# Altium schematic sheet Sheet1.SchDoc
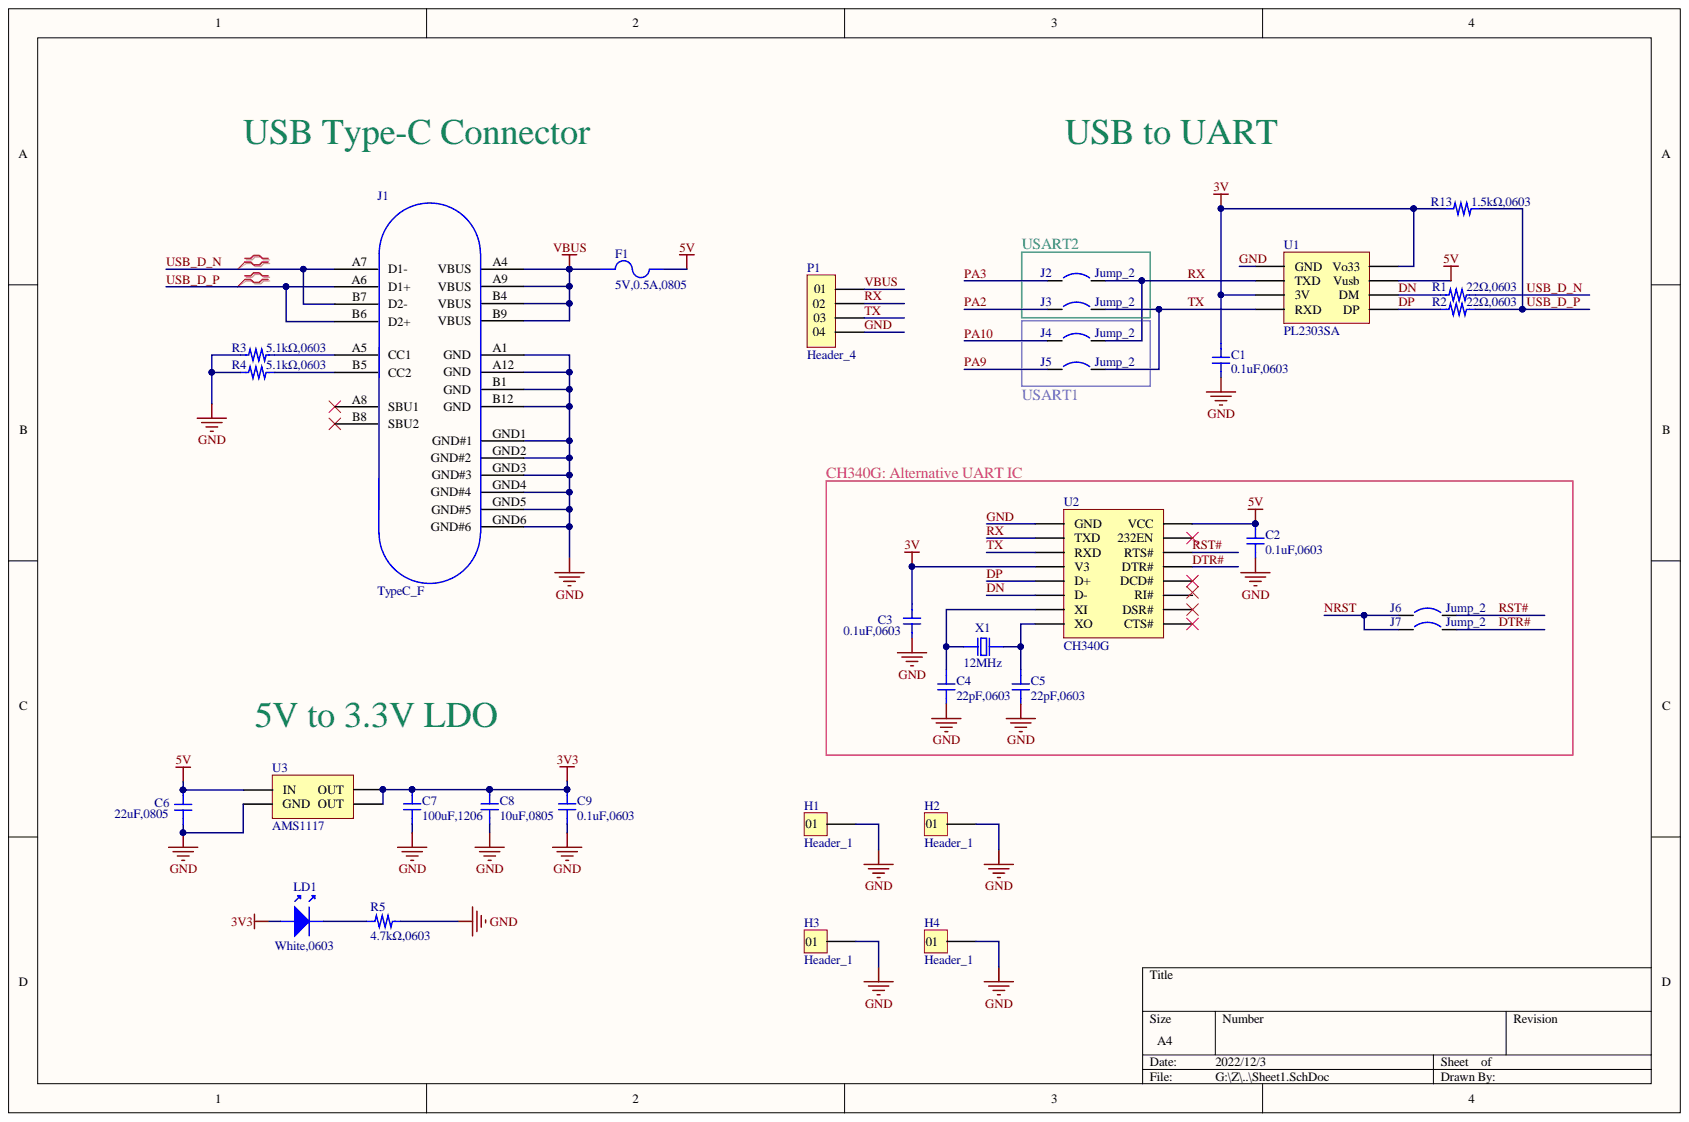
[diagram p0: Sheet1.SchDoc]

## Parts
C1  0.1uF,0603  [C0603]
C2  0.1uF,0603  [C0603]
C3  0.1uF,0603  [C0603]
C4  22pF,0603  [C0603]
C5  22pF,0603  [C0603]
C6  22uF,0805  [C0805]
C7  100uF,1206  [C1206]
C8  10uF,0805  [C0805]
C9  0.1uF,0603  [C0603]
F1  5V,0.5A,0805  [F0805]
H1  Header_1  [Header_1_GND]
H2  Header_1  [Header_1_GND]
H3  Header_1  [Header_1_GND]
H4  Header_1  [Header_1_GND]
J1  TypeC_F  [Type-C_16P_F_0.5_BOTH]
J2  Jump_2  [Jp_small]
J3  Jump_2  [Jp_small]
J4  Jump_2  [Jp_small]
J5  Jump_2  [Jp_small]
J6  Jump_2  [Jp_small]
J7  Jump_2  [Jp_small]
LD1  White,0603  [LED0603]
P1  Header_4  [Header_4]
R1  22Ω,0603  [R0603]
R2  22Ω,0603  [R0603]
R3  5.1kΩ,0603  [R0603]
R4  5.1kΩ,0603  [R0603]
R5  4.7kΩ,0603  [R0603]
R13  1.5kΩ,0603  [R0603]
U1  PL2303SA  [SOP8]
U2  CH340G  [SOP16]
U3  AMS1117  [SOT-223]
X1  12MHz  [XTAL_SMD_3225]

## Nets
3V: C1.02 C3.02 R13.01 U1.03(3V) U1.08(Vo33) U2.04(V3)
3V3: C7.02 C8.02 C9.02 LD1.01 U3.02(OUT) U3.04(OUT)
5V: C2.02 C6.02 F1.02 U1.07(Vusb) U2.16(VCC) U3.03(IN)
DN: R1.01 U1.06(DM) U2.06(D-)
DP: R2.01 U1.05(DP) U2.05(D+)
DTR#: J7.02 U2.13(DTR#)
GND: C1.01 C2.01 C3.01 C4.01 C5.01 C6.01 C7.01 C8.01 C9.01 H1.01 H2.01 H3.01 H4.01 J1.A1(GND) J1.A12(GND) J1.B1(GND) J1.B12(GND) J1.GND1(GND#1) J1.GND2(GND#2) J1.GND3(GND#3) J1.GND4(GND#4) J1.GND5(GND#5) J1.GND6(GND#6) P1.04 R3.01 R4.01 R5.01 U1.01(GND) U2.01(GND) U3.01(GND)
NRST: J6.01 J7.01
PA2: J3.01
PA3: J2.01
PA9: J5.01
PA10: J4.01
RST#: J6.02 U2.14(RTS#)
RX: J2.02 J4.02 P1.02 U1.02(TXD) U2.02(TXD)
TX: J3.02 J5.02 P1.03 U1.04(RXD) U2.03(RXD)
USB_D_N: J1.A7(D1-) J1.B7(D2-) R1.02
USB_D_P: J1.A6(D1+) J1.B6(D2+) R2.02 R13.02
VBUS: F1.01 J1.A4(VBUS) J1.A9(VBUS) J1.B4(VBUS) J1.B9(VBUS) P1.01
NetC4_02: C4.02 U2.07(XI) X1.01
NetC5_02: C5.02 U2.08(XO) X1.02
NetJ1_A5: J1.A5(CC1) R3.02
NetJ1_B5: J1.B5(CC2) R4.02
NetLD1_02: LD1.02 R5.02

Not connected: J1 A8(SBU1) B8(SBU2); U2 09(CTS#) 10(DSR#) 11(RI#) 12(DCD#) 15(232EN)

## Library data of the parts
C1-C9 = Cap: Component Kind: Standard; Library Name: myLib1.IntLib
F1 = Fuse: Component Kind: Standard; Library Name: myLib1.IntLib
J1 = Type-C_16P_F_0.5: Female SMD Connector; Component Kind: Standard; Library Name: myLib1.IntLib
LD1 = Diode - LED: Component Kind: Standard; Library Name: myLib1.IntLib
R1-R5 R13 = Res: Component Kind: Standard; Library Name: myLib1.IntLib
X1 = XTAL: Component Kind: Standard; Library Name: myLib1.IntLib
H1-H4 = Header_1: Component Kind: Standard; Library Name: myLib1.IntLib
J2-J7 = Jump_2: Component Kind: Standard; Library Name: myLib1.IntLib
P1 = Header_4: Component Kind: Standard; Library Name: myLib1.IntLib
U1 = PL2303SA: Component Kind: Standard; Library Name: myLib1.IntLib
U2 = CH340G: Component Kind: Standard; Library Name: myLib1.IntLib
U3 = AMS1117: Component Kind: Standard; Library Name: myLib1.IntLib
